# Altium schematic sheet Vapeix_mini_Shield.SchDoc
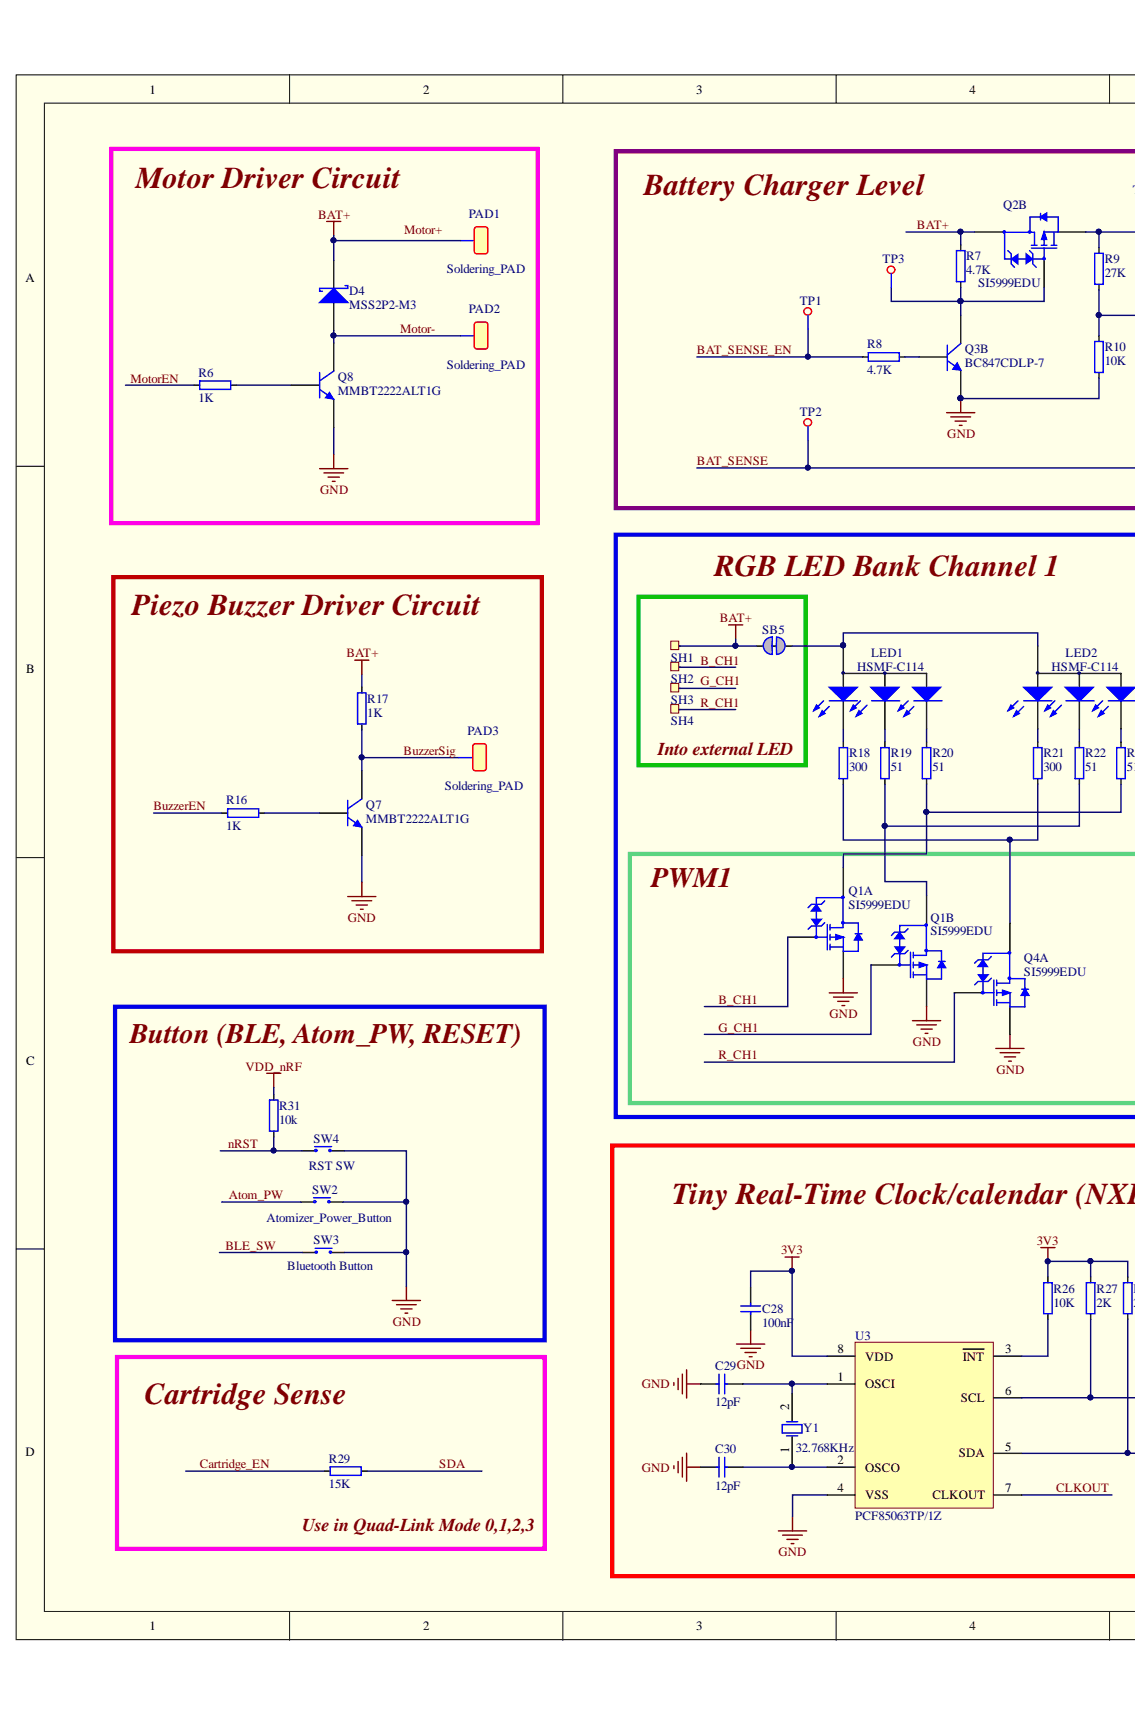
[diagram p0: Vapeix_mini_Shield.SchDoc, left]
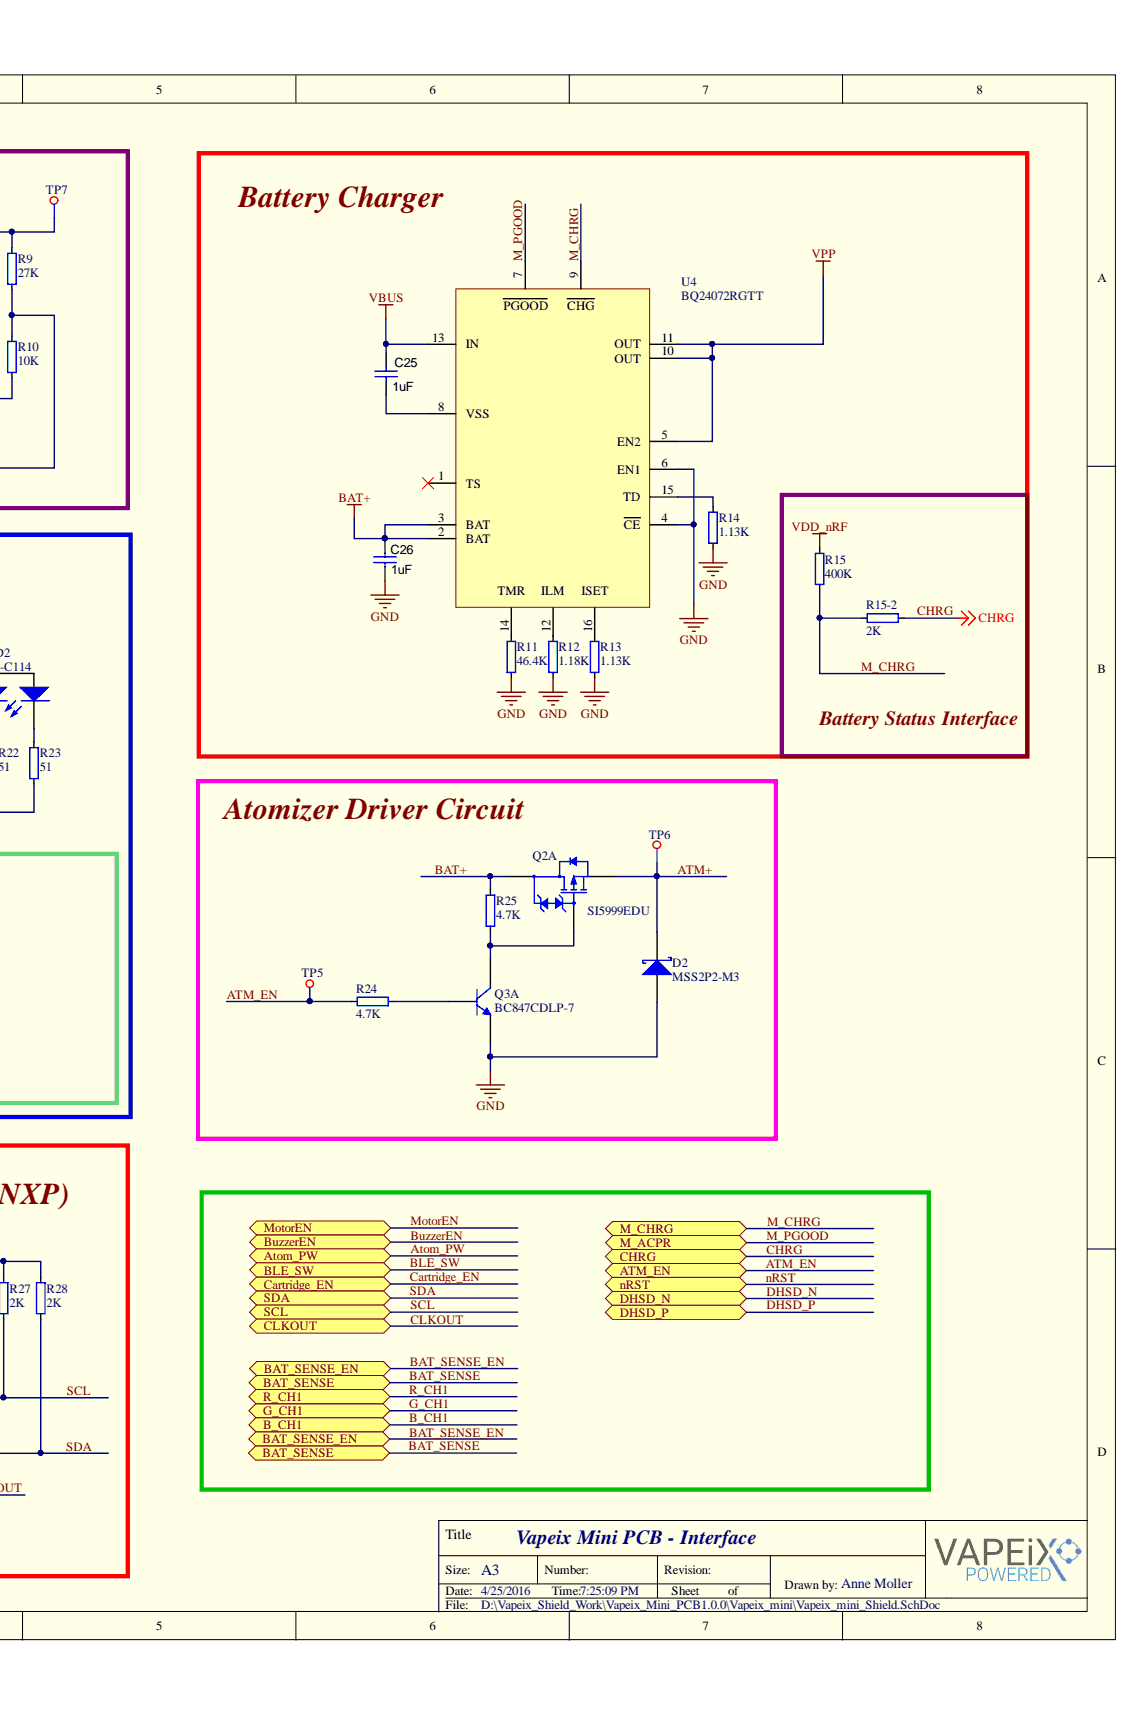
[diagram p1: Vapeix_mini_Shield.SchDoc, right]

## Parts
C25  ValueDisplayed: 1uF  [CAPC1608X06L]
C26  ValueDisplayed: 1uF  [CAPC1608X06L]
C28  100nF  [CAPC1005X04L]
C29  12pF  [CAPC1005X04L]
C30  12pF  [CAPC1005X04L]
D2  MSS2P2-M3  [MSS2P2-M3]
D4  MSS2P2-M3  [MSS2P2-M3]
LED1  HSMF-C114  [HSMF-C114]
LED2  HSMF-C114  [HSMF-C114]
PAD1  Soldering_PAD  [Soldering_PAD]
PAD2  Soldering_PAD  [Soldering_PAD]
PAD3  Soldering_PAD  [Soldering_PAD]
Q1  SI5999EDU  [SI5999EDU]  (parts A,B)
Q2  SI5999EDU  [SI5999EDU]  (parts A,B)
Q3  BC847CDLP-7  [BC847CDLP-7]  (parts A,B)
Q4  SI5999EDU  [SI5999EDU]  (part A)
Q7  MMBT2222ALT1G  [MMBT2222ALT1G]
Q8  MMBT2222ALT1G  [MMBT2222ALT1G]
R6  1K  [RESC1005X04L]
R7  4.7K  [RESC1005X04L]
R8  4.7K  [RESC1005X04L]
R9  27K  [RESC1005X04L]
R10  10K  [RESC1005X04L]
R11  46.4K  [RESC1005X04L]
R12  1.18K  [RESC1005X04L]
R13  1.13K  [RESC1005X04L]
R14  1.13K  [RESC1005X04L]
R15  400K  [RESC1005X04L]
R15-2  2K  [RESC1005X04L]
R16  1K  [RESC1005X04L]
R17  1K  [RESC1005X04L]
R18  300  [RESC1005X04L]
R19  51  [RESC1005X04L]
R20  51  [RESC1005X04L]
R21  300  [RESC1005X04L]
R22  51  [RESC1005X04L]
R23  51  [RESC1005X04L]
R24  4.7K  [RESC1005X04L]
R25  4.7K  [RESC1005X04L]
R26  10K  [RESC1005X04L]
R27  2K  [RESC1005X04L]
R28  2K  [RESC1005X04L]
R29  15K  [RESC1005X04L]
R31  10k  [RESC1005X04L]
SB5  Solderbridge  [Solderbridge_Shorted]  (value not drawn)
SH1  TP  [TESTPAD-TH]  (value not drawn)  (no BOM)
SH2  TP  [TESTPAD-TH]  (value not drawn)  (no BOM)
SH3  TP  [TESTPAD-TH]  (value not drawn)  (no BOM)
SH4  TP  [TESTPAD-TH]  (value not drawn)  (no BOM)
SW2  Atomizer_Power_Button  [ALPS_SKHUxxx010_WO_GND]
SW3  Bluetooth Button  [ALPS_SKHUxxx010_WO_GND]
SW4  RST SW  [ALPS_SKHUxxx010_WO_GND]
TP1  TP  [TP]
TP2  TP  [TP]
TP3  TP  [TP]
TP5  TP  [TP]
TP6  TP  [TP]
TP7  TP  [TP]
U3  PCF85063TP/1Z  [PCF85063TP]
U4  BQ24072RGTT  [BQ24072RGTT]
Y1  32.768KHz  [CFS206-32.768KDZF-UB]

## Ports
ATM_EN, ATOM_PW, B_CH1, BAT_SENSE, BAT_SENSE_EN, BLE_SW, BUZZEREN, CARTRIDGE_EN, CHRG, CLKOUT, DHSD_N, DHSD_P, G_CH1, M_ACPR, M_CHRG, MOTOREN, NRST, R_CH1, SCL, SDA

## Nets
3V3: C28.1 R26.2 R27.2 R28.2 U3.8(VDD)
GND: C26.2 C28.2 C29.1 C30.1 D2.1 Q1.5 Q1.7 Q3.1 Q3.4 Q4.7 Q7.2 Q8.2 R10.2 R11.2 R12.2 R13.2 R14.2 U3.4(VSS) U4.4(CE) U4.6(EN1)
VBUS: C25.1 U4.13(IN)
VDD_NRF: R15.1 R31.2
VPP: U4.5(EN2) U4.10(OUT) U4.11(OUT)
ATM+: D2.2 Q2.7 TP6.1
ATM_EN: R24.1 TP5.1 ; port ATM_EN
ATOM_PW: SW2.1 ; port ATOM_PW
B_CH1: Q1.2 SH2.1 ; port B_CH1
BAT+: C26.1 D4.2 PAD1.1 Q2.1 Q2.3 R7.1 R17.1 R25.2 SB5.2 SH1.1 U4.2(BAT) U4.3(BAT)
BAT_SENSE: R9.2 R10.1 TP2.1 ; port BAT_SENSE
BAT_SENSE_EN: R8.2 TP1.1 ; port BAT_SENSE_EN
BLE_SW: SW3.1 ; port BLE_SW
BUZZEREN: R16.2 ; port BUZZEREN
BUZZERSIG: PAD3.1 Q7.3 R17.2
CARTRIDGE_EN: R29.2 ; port CARTRIDGE_EN
CHRG: R15-2.1 ; port CHRG
CLKOUT: U3.7(CLKOUT) ; port CLKOUT
G_CH1: Q1.4 SH3.1 ; port G_CH1
M_CHRG: R15.2 R15-2.2 U4.9 ; port M_CHRG
M_PGOOD: U4.7 ; port M_ACPR
MOTOR-: D4.1 PAD2.1 Q8.3
MOTOREN: R6.2 ; port MOTOREN
NRST: R31.1 SW4.1 ; port NRST
R_CH1: Q4.2 SH4.1 ; port R_CH1
SCL: R27.1 U3.6(SCL) ; port SCL
SDA: R28.1 R29.1 U3.5(SDA) ; port SDA
NetC25_2: C25.2 U4.8(VSS)
NetC29_2: C29.2 U3.1(OSCI) Y1.2
NetC30_2: C30.2 U3.2(OSCO) Y1.1
NetLED1_1: LED1.1 LED2.1 SB5.1
NetLED1_2: LED1.2 R18.1
NetLED1_3: LED1.3 R19.1
NetLED1_4: LED1.4 R20.1
NetLED2_2: LED2.2 R21.1
NetLED2_3: LED2.3 R22.1
NetLED2_4: LED2.4 R23.1
NetQ1_1: Q1.1 R20.2 R23.2
NetQ1_3: Q1.3 R19.2 R22.2
NetQ2_2: Q2.2 Q3.3 R25.1
NetQ2_4: Q2.4 Q3.6 R7.2 TP3.1
NetQ2_5: Q2.5 R9.1 TP7.1
NetQ3_2: Q3.2 R24.2
NetQ3_5: Q3.5 R8.1
NetQ4_1: Q4.1 R18.2 R21.2
NetQ7_1: Q7.1 R16.1
NetQ8_1: Q8.1 R6.1
NetR11_1: R11.1 U4.14
NetR12_1: R12.1 U4.12
NetR13_1: R13.1 U4.16
NetR14_1: R14.1 U4.15(TD)
NetR26_1: R26.1 U3.3(INT)
NetSW2_2: SW2.2 SW3.2 SW4.2
ATOMIZER DRIVER CIRCUIT:
BATTERY CHARGER:
BATTERY CHARGER LEVEL:
BATTERY STATUS INTERFACE:
BUTTON (BLE, ATOM_PW, RESET):
CARTRIDGE SENSE:
DHSD_N: ; port DHSD_N
DHSD_P: ; port DHSD_P
INTO EXTERNAL LED:
MOTOR DRIVER CIRCUIT:
MOTOR+:
PIEZO BUZZER DRIVER CIRCUIT:
PWM1:
RGB LED BANK CHANNEL 1:
TINY REAL-TIME CLOCK/CALENDAR (NXP):
USE IN QUAD-LINK MODE 0,1,2,3:

Not connected: U4 1(TS)

## Library data of the parts
C25 C26 = GRM219R61A106KE44D: CAP, CERM, 10 µF, 10 V, +/- 10%, X5R, 0805; Manufacturer: MuRata; Cap_Case: 0805; Cap_F: 0.00001; CompType: Capacitor; ESR_ohm: 0.005; Polarized: N; TaMax_C: 85; TaMin_C: -55; Tolerance_%: 10; U/M: EA; Value: 10uF; Value1: 0.005 ohm; Value2: 10V; Component Kind: Standard; ComponentLink1Description: Datasheet link; ComponentLink1URL: http://psearch.murata.com/capacitor/product/GRM219R61A106KE44%23.pdf; LatestRevisionDate: 2014-08-19; Library Name: Capacitor_Ceramic.IntLib; MountType: SMT; PackageReference: 0805; PartNumber: GRM219R61A106KE44D; Published: 2008-06-19; RoHS: Y; Signal Integrity: Cap; Simulation: Cap; Supplier 1: Digi-Key; Supplier 2: Mouser; Supplier 3: Farnell; Supplier Part Number 1: 490-4735-1-ND; Supplier Part Number 2: 81-GRM219R61A106KE4D; Supplier Part Number 3: 1845741; SymbolVersion: 2008-07-09; Technology: Ceramic; TempCo: X5R; VDC_V: 10; Publisher: Texas Instruments
C28-C30 = C0402-100n: Capacitor, X7R, ±10%; Artikkelnr Noca: 20039200; Artikkelnr Simpro: N.A.; Database Table Name: Capacitors$; Note: 16V; Component Kind: Standard; Footprint Doc: 0402; LatestRevisionDate: 2009-11-23; Library Name: Nordic Passive DB.DbLib; Part Number: C0402-100n; Pin Count: 2; Published: 2009-05-08; Publisher: Nordic Semiconductor
  C28: Value: 100nF
  C29 C30: Value: 12pF
D2 D4 = MSS2P2-M3/89A: DIODE SCHOTTKY 20V 2A MICROSMP; Manufacturer: Vishay Semiconductor Diodes Division; Capacitance @ Vr, F: 65pF @ 4V, 1MHz; Current - Reverse Leakage @ Vr: 250µA @ 20V; Speed: Fast Recovery =< 500ns, > 200mA (Io); Voltage - Forward (Vf) (Max) @ If: 600mV @ 2A; Category: Discrete Semiconductor Products; Component Kind: Standard; ComponentLink1Description: http://www.vishay.com/docs/89054/mss2p3.pdf; ComponentLink1URL: http://www.vishay.com/docs/89054/mss2p3.pdf; Current - Average Rectified (Io): 2A; Diode Type: Schottky; Manufacturer Part Number: MSS2P2-M3/89A; Mounting Type: Surface Mount; Operating Temperature - Junction: -55°C ~ 150°C; Package / Case: MicroSMP; Packaging: Digi-Reel®; Pricing 1: 1=0.37, 10=0.274, 100=0.1552, 500=0.1029, 1000=0.07892 (USD); Reverse Recovery Time (trr): -; RoHS: RoHS Compliant; Stock 1: 3961; Supplier 1: Digi-Key; Supplier Device Package: MicroSMP; Voltage - DC Reverse (Vr) (Max): 20V; Library Name: VapeixS_LIB.SchLib
LED1 LED2 = HSMF-C114: LED RGB DIFFUSED 0606 SMD; Manufacturer: Broadcom Limited; Color: Red, Green, Blue (RGB); Current - Test: 20mA Red, 20mA Green, 20mA Blue; Lens Color: White; Lens Style/Size: Rectangle with Flat Top, 1.10mm x 1.50mm; Millicandela Rating: 85mcd Red, 180mcd Green, 70mcd Blue; Viewing Angle: 140° Red, 145° Green, 145° Blue; Voltage - Forward (Vf) (Typ): 1.9V Red, 3.4V Green, 3.4V Blue; Wavelength - Dominant: 626nm Red, 525nm Green, 470nm Blue; Wavelength - Peak: 637nm Red, 523nm Green, 468nm Blue; Category: Optoelectronics; Component Kind: Standard; ComponentLink1Description: http://www.avagotech.com/docs/AV02-2451EN; ComponentLink1URL: http://www.avagotech.com/docs/AV02-2451EN; Features: -; Height (Max): 0.35mm; Lens Transparency: Diffused; Manufacturer Part Number: HSMF-C114; Mounting Type: Surface Mount; Package / Case: 0606 (1616 Metric); Packaging: Digi-Reel®; Pricing 1: 1=2.03, 10=1.62, 25=1.2376, 100=1.125, 250=0.99, 500=0.8325, 1000=0.72 (USD); RoHS: RoHS Compliant; Size / Dimension: 1.60mm L x 1.50mm W; Stock 1: 11787; Supplier 1: Digi-Key; Supplier Device Package: Chip LED; Library Name: VapeixS_LIB.SchLib
PAD1-PAD3 = Soldering_PAD: Soldering PAD; Component Kind: Standard; Library Name: VapeixS_LIB.SchLib
Q1 Q2 Q4 = SI5999EDU-T1-GE3: MOSFET 2P-CH 20V 6A POWERPAK; Manufacturer: Vishay Siliconix; FET Feature: Logic Level Gate; FET Type: 2 P-Channel (Dual); Gate Charge (Qg) @ Vgs: 20nC @ 10V; Input Capacitance (Ciss) @ Vds: 496pF @ 10V; Power - Max: 10.4W; Rds On (Max) @ Id, Vgs: 59 mOhm @ 3.5A, 4.5V; Vgs(th) (Max) @ Id: 1.5V @ 250µA; Category: Discrete Semiconductor Products; Component Kind: Standard; ComponentLink1Description: http://www.vishay.com/docs/67019/si5999ed.pdf; ComponentLink1URL: http://www.vishay.com/docs/67019/si5999ed.pdf; Current - Continuous Drain (Id) @ 25°C: 6A; Drain to Source Voltage (Vdss): 20V; Manufacturer Part Number: SI5999EDU-T1-GE3; Mounting Type: Surface Mount; Operating Temperature: -55°C ~ 150°C (TJ); Package / Case: PowerPAK® ChipFET™ Dual; Packaging: Cut Tape (CT); Pricing 1: 1=0.58, 10=0.51, 100=0.39, 500=0.309, 1000=0.2475 (USD); RoHS: RoHS Compliant; Stock 1: 2994; Supplier 1: Digi-Key; Supplier Device Package: PowerPAK® ChipFet Dual; Library Name: VapeixS_LIB.SchLib
Q3 = BC847CDLP-7: TRANS 2NPN 45V 0.1A 6DFN; Manufacturer: Diodes Incorporated; Current - Collector (Ic) (Max): 100mA; Current - Collector Cutoff (Max): 15nA; DC Current Gain (hFE) (Min) @ Ic, Vce: 420 @ 2mA, 5V; Frequency - Transition: 100MHz; Power - Max: 350mW; Transistor Type: 2 NPN (Dual); Vce Saturation (Max) @ Ib, Ic: 600mV @ 5mA, 100mA; Category: Discrete Semiconductor Products; Component Kind: Standard; ComponentLink1Description: http://www.diodes.com/_files/datasheets/ds30817.pdf; ComponentLink1URL: http://www.diodes.com/_files/datasheets/ds30817.pdf; Manufacturer Part Number: BC847CDLP-7; Mounting Type: Surface Mount; Package / Case: 6-SMD, No Lead; Packaging: Cut Tape (CT); Pricing 1: 1=0.36, 10=0.29, 100=0.198, 500=0.1482, 1000=0.111 (USD); RoHS: RoHS Compliant; Stock 1: 11845; Supplier 1: Digi-Key; Supplier Device Package: 6-DFN1310H4 (1.0x1.3); Voltage - Collector Emitter Breakdown (Max): 45V; Library Name: VapeixS_LIB.SchLib
Q7 Q8 = MMBT2222ALT1G: TRANS NPN 40V 0.6A SOT23; Manufacturer: ON Semiconductor; Current - Collector (Ic) (Max): 600mA; Current - Collector Cutoff (Max): 10nA (ICBO); DC Current Gain (hFE) (Min) @ Ic, Vce: 100 @ 150mA, 10V; Frequency - Transition: 300MHz; Power - Max: 300mW; Vce Saturation (Max) @ Ib, Ic: 1V @ 50mA, 500mA; Category: Discrete Semiconductor Products; Component Kind: Standard; ComponentLink1Description: http://www.onsemi.com/pub_link/Collateral/MMBT2222LT1-D.PDF; ComponentLink1URL: http://www.onsemi.com/pub_link/Collateral/MMBT2222LT1-D.PDF; Manufacturer Part Number: MMBT2222ALT1G; Mounting Type: Surface Mount; Package / Case: TO-236-3, SC-59, SOT-23-3; Packaging: Cut Tape (CT); Pricing 1: 1=0.1, 10=0.095, 100=0.0516, 500=0.03172, 1000=0.02163 (USD); RoHS: RoHS Compliant; Stock 1: 0; Supplier 1: Digi-Key; Supplier Device Package: SOT-23; Transistor Type: NPN; Voltage - Collector Emitter Breakdown (Max): 40V; Library Name: VapeixS_LIB.SchLib
R6-R10 R15 R15-2 R16-R29 R31 = R0402-10k: Resistor, ±1%, 0.063W; Artikkelnr Noca: 27049097; Artikkelnr Simpro: N.A.; Database Table Name: Resistors$; Note: Chip Res 1%; Component Kind: Standard; Footprint Doc: 0402; LatestRevisionDate: 2009-11-23; Library Name: Nordic Passive DB.DbLib; Part Number: R0402-10k; Pin Count: 2; Published: 2009-05-08; Supplier: Farnell; Supplier part number: 173-8864; Publisher: Nordic Semiconductor
  R6 R16 R17: Value: 1K
  R7 R8 R24 R25: Value: 4.7K
  R9: Value: 27K
  R10 R26: Value: 10K
  R15: Value: 400K
  R15-2 R27 R28: Value: 2K
  R18 R21: Value: 300
  R19 R20 R22 R23: Value: 51
  R29: Value: 15K
  R31: Value: 10k
R11-R14 = R0402-10k: Resistor, ±1%, 0.063W; Artikkelnr Noca: 27049097; Artikkelnr Simpro: N.A.; Database Table Name: Resistors$; Note: Chip Res 1%; Value: 10K; Component Kind: Standard; Footprint Doc: 0402; LatestRevisionDate: 2009-11-23; Library Name: Nordic Passive DB.DbLib; Part Number: R0402-10k; Pin Count: 2; Published: 2009-05-08; Supplier: Farnell; Supplier part number: 173-8864; Publisher: Nordic Semiconductor
SB5 = Solderbridge Shorted: Shorted Solderbridge; Artikkelnr Noca: N.A.; Database Table Name: Connectors$; Artikkelnr Simpro: N.A.; Component Kind: Net Tie; Footprint Doc: Solderbridge_Shorted; LatestRevisionDate: 2012-08-07; Library Name: Nordic Passive DB.DbLib; Part Number: Solderbridge Shorted; Pin Count: 2; Published: 2012-08-07; Value: Solderbridge; Publisher: Nordic Semiconductor
SH1-SH4 = (no symbol name): Testpoint, through hole; Part Number: Testpoint TH; Artikkelnr Noca: N.A.; Artikkelnr Simpro: N.A.; Footprint Doc: TESTPAD-TH; LatestRevisionDate: 2009-12-12; Library Name: pca10040.SCHLIB; Pin Count: 1; Published: 2009-12-12; Value: TP; Publisher: Nordic Semiconductor
SW2 SW3 = ALPS_SKHUALE010: ALPS - SKHUALE010 - Tactile Switch, SPNO, SMD, 6.5x6.2x2.5mm; 2nd source part number: 688-SKHUALE010; Artikkelnr Noca: 50019001; Artikkelnr Simpro: N.A.; Database Table Name: Rele_Switch$; Value: RST SW; 2nd source Supplier: Mouser; Component Kind: Standard; Datasheet: https://www1.elfa.se/data1/wwwroot/webroot/Z_DATA/03579000.pdf; Footprint Doc: ALPS_SKHUxxx010_WO_GND; LatestRevisionDate: 2010-02-01; Library Name: Nordic Discrete DB.DbLib; Manufacturer: Alps; Manufacturer Part Number: SKHUALE010; Part Number: ALPS_SKHUALE010; Pin Count: 4; Published: 2010-02-01; Supplier: Elfa; Supplier part number: 35-790-00; Publisher: Nordic Semiconductor
SW4 = ALPS_SKHUALE010: ALPS - SKHUALE010 - Tactile Switch, SPNO, SMD, 6.5x6.2x2.5mm; 2nd source part number: 688-SKHUALE010; Artikkelnr Noca: 50019001; Artikkelnr Simpro: N.A.; Database Table Name: Rele_Switch$; 2nd source Supplier: Mouser; Component Kind: Standard; Datasheet: https://www1.elfa.se/data1/wwwroot/webroot/Z_DATA/03579000.pdf; Footprint Doc: ALPS_SKHUxxx010_WO_GND; LatestRevisionDate: 2010-02-01; Library Name: Nordic Discrete DB.DbLib; Manufacturer: Alps; Manufacturer Part Number: SKHUALE010; Part Number: ALPS_SKHUALE010; Pin Count: 4; Published: 2010-02-01; Supplier: Elfa; Supplier part number: 35-790-00; Value: RST SW; Publisher: Nordic Semiconductor
TP1-TP3 TP5-TP7 = TP: TEST POINT; Component Kind: Standard; Library Name: VapeixS_LIB.SchLib
U3 = PCF85063TP/1Z: IC RTC CLK/CALENDAR I2C 8-SON; Manufacturer: NXP Semiconductors; Current - Timekeeping (Max): 0.6µA @ 3.3V; Date Format: YY-MM-DD-dd; Interface: I²C, 2-Wire Serial; Time Format: HH:MM:SS (12/24 hr); Voltage - Supply: 0.9 V ~ 5.5 V; Category: Integrated Circuits (ICs); Component Kind: Standard; ComponentLink1Description: http://www.nxp.com/documents/data_sheet/PCF85063TP.pdf; ComponentLink1URL: http://www.nxp.com/documents/data_sheet/PCF85063TP.pdf; Features: Alarm, Leap Year, Square Wave Output; Manufacturer Part Number: PCF85063TP/1Z; Memory Size: -; Mounting Type: Surface Mount; Operating Temperature: -40°C ~ 85°C; Package / Case: 8-WFDFN Exposed Pad; Packaging: Cut Tape (CT); Pricing 1: 1=0.74, 10=0.646, 100=0.494, 500=0.3914, 1000=0.3135 (USD); RoHS: RoHS Compliant; Stock 1: 17308; Supplier 1: Digi-Key; Supplier Device Package: 8-HWSON (2x3); Supplier Part Number 1: 568-10236-1-ND; Type: Clock/Calendar; Voltage - Supply, Battery: -; Library Name: VapeixS_LIB.SchLib
U4 = BQ24072RGTT: TEXAS INSTRUMENTS - BQ24072RGTT - BATTERY CHARGER LI-ION, 1.5A, QFN-16; Battery Charge Voltage: 4.2; Battery IC Case Style: QFN; Charge Current Max: 1.2; Input Voltage: 26; No. of Pins: 16; No. of Series Cells: 1; Battery Type: Li-Ion; Component Kind: Standard; ComponentLink1Description: http://www.farnell.com/cad/1638885.zip; ComponentLink1URL: http://www.farnell.com/cad/1638885.zip; ComponentLink2Description: http://www.farnell.com/datasheets/2001589.pdf; ComponentLink2URL: http://www.farnell.com/datasheets/2001589.pdf; Manufacturer: TEXAS INSTRUMENTS; Manufacturer Part Number: BQ24072RGTT; Operating Temperature Min: -40 C; Pricing 1: 1=1.6, 10=1.6, 25=1.47, 50=1.47, 100=1.47 (USD); RoHS: YES; Stock 1: 134; Supplier 1: Newark; Supplier Part Number 1: 12P6258; Library Name: VapeixS_LIB.SchLib
Y1 = CFS206-32.768KDZF-UB: Crystals 32.768 KHz 12.5pF CYLINDER CRYSTAL; Brand: Citizen FineDevice; ESR: 35 kOhms; Tolerance: 20 PPM; Category: Crystals; Component Kind: Standard; ComponentLink1Description: http://www.mouser.com/ds/2/77/CFS-CFV-4402.pdf; ComponentLink1URL: http://www.mouser.com/ds/2/77/CFS-CFV-4402.pdf; Diameter: 2 mm; Frequency: 32.768 kHz; Lead Spacing: 0.7 mm; Length: 6 mm; Load Capacitance: 12.5 pF; Manufacturer: Citizen FineDevice; Manufacturer Part Number: CFS206-32.768KDZF-UB; Minimum Order Quantity: 1; Mounting Style: Through Hole; Operating Temperature Range: - 20 C to + 70 C; Package / Case: Cylinder; Packaging: Bulk; Pricing 1: 1=0.300, 10=0.260, 50=0.220, 100=0.180, 500=0.160, 1000=0.140, 2000=0.130, 5000=0.120, 10000=0.110 (USD); Product Category: KHz Range Crystals; Reeling: False; RoHS: RoHS Compliant; RoHS - Mouser: Y; Series: CFS-206/CFS-145/CFV-206; Standard Pack Qty: 1000; Stock 1: 8057; Supplier 1: Mouser; Termination Style: Radial; Type: Tuning Fork Crystal; Library Name: VapeixS_LIB.SchLib
